# Altium schematic sheet 01-CM4_Conn.SchDoc(01-CM4_Conn)
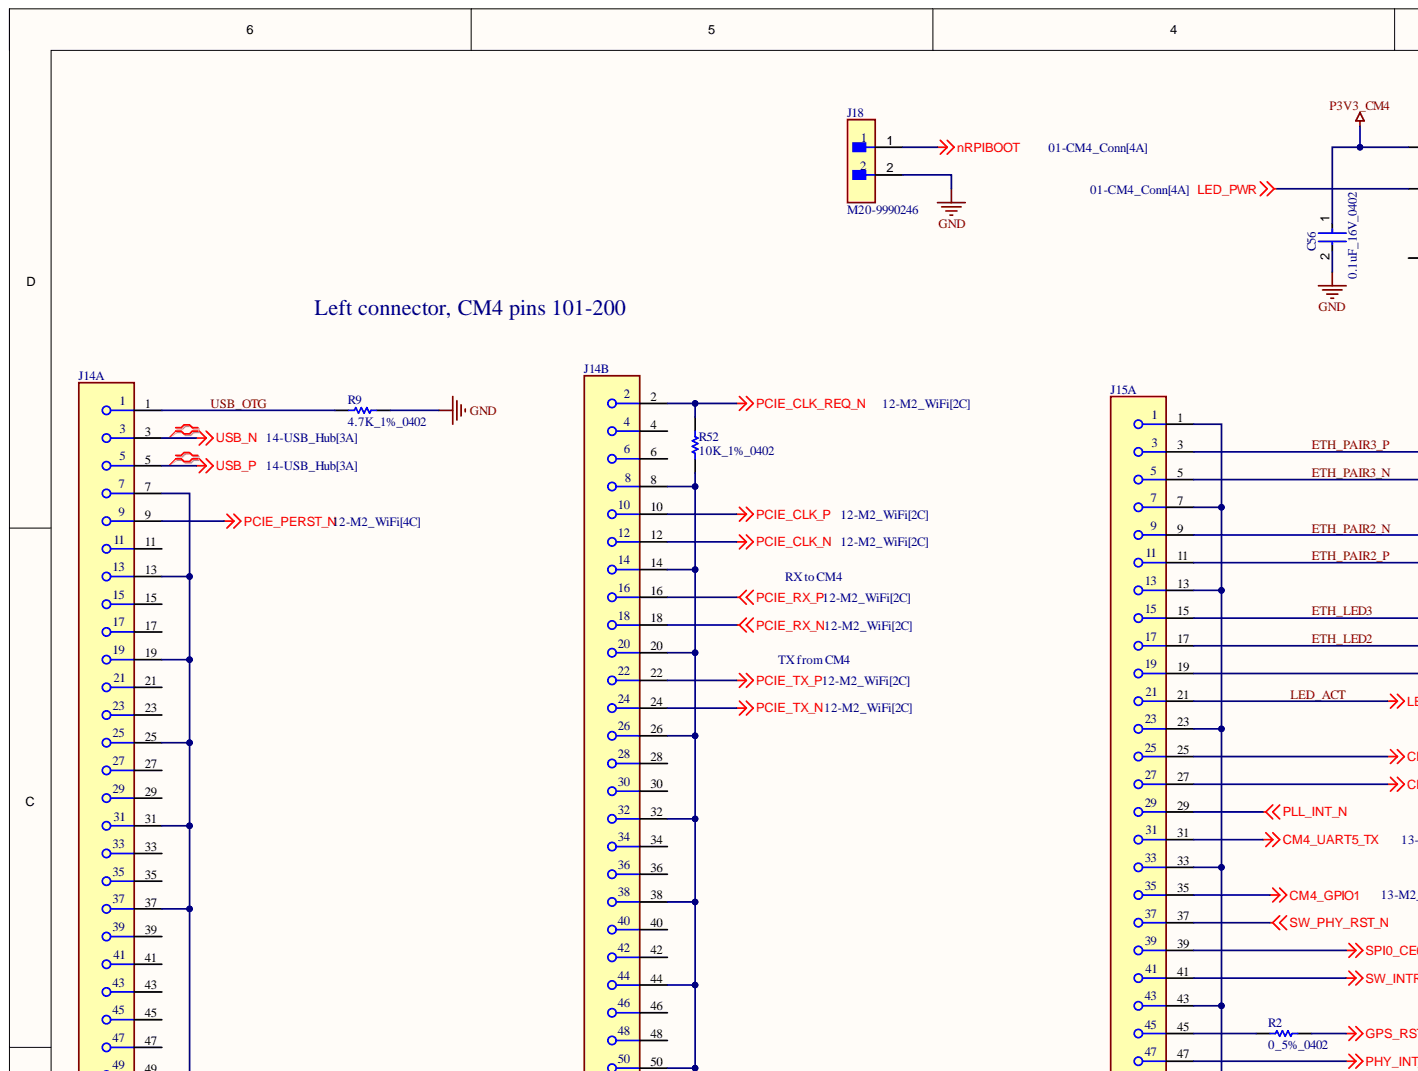
[diagram p0: 01-CM4_Conn.SchDoc, top left]
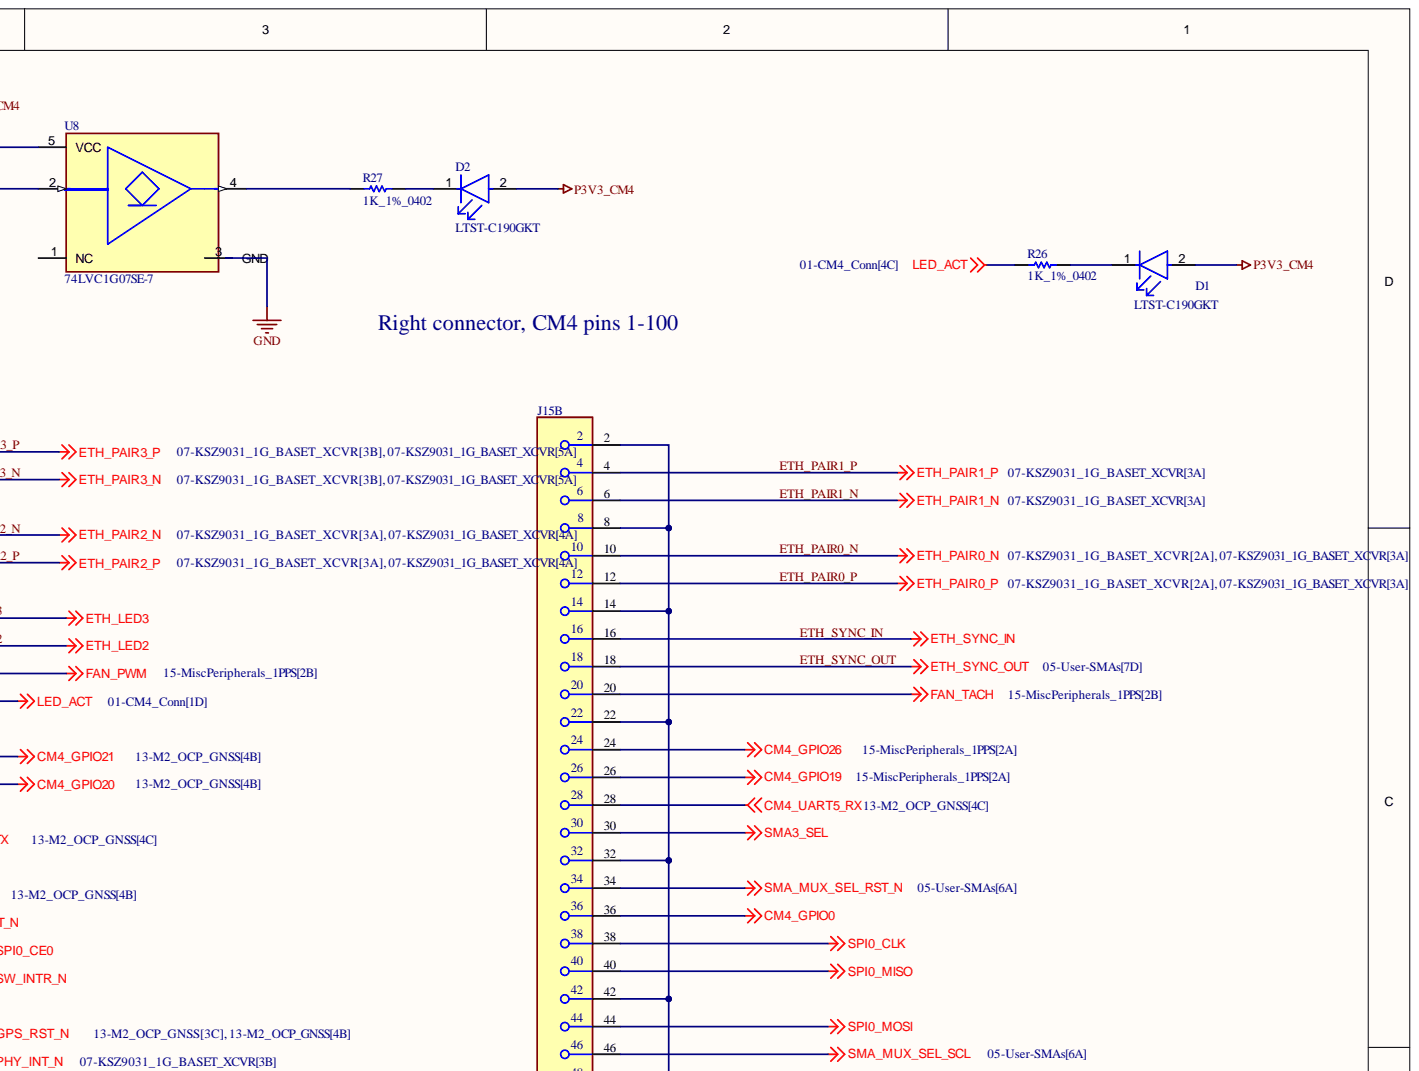
[diagram p1: 01-CM4_Conn.SchDoc, top right]
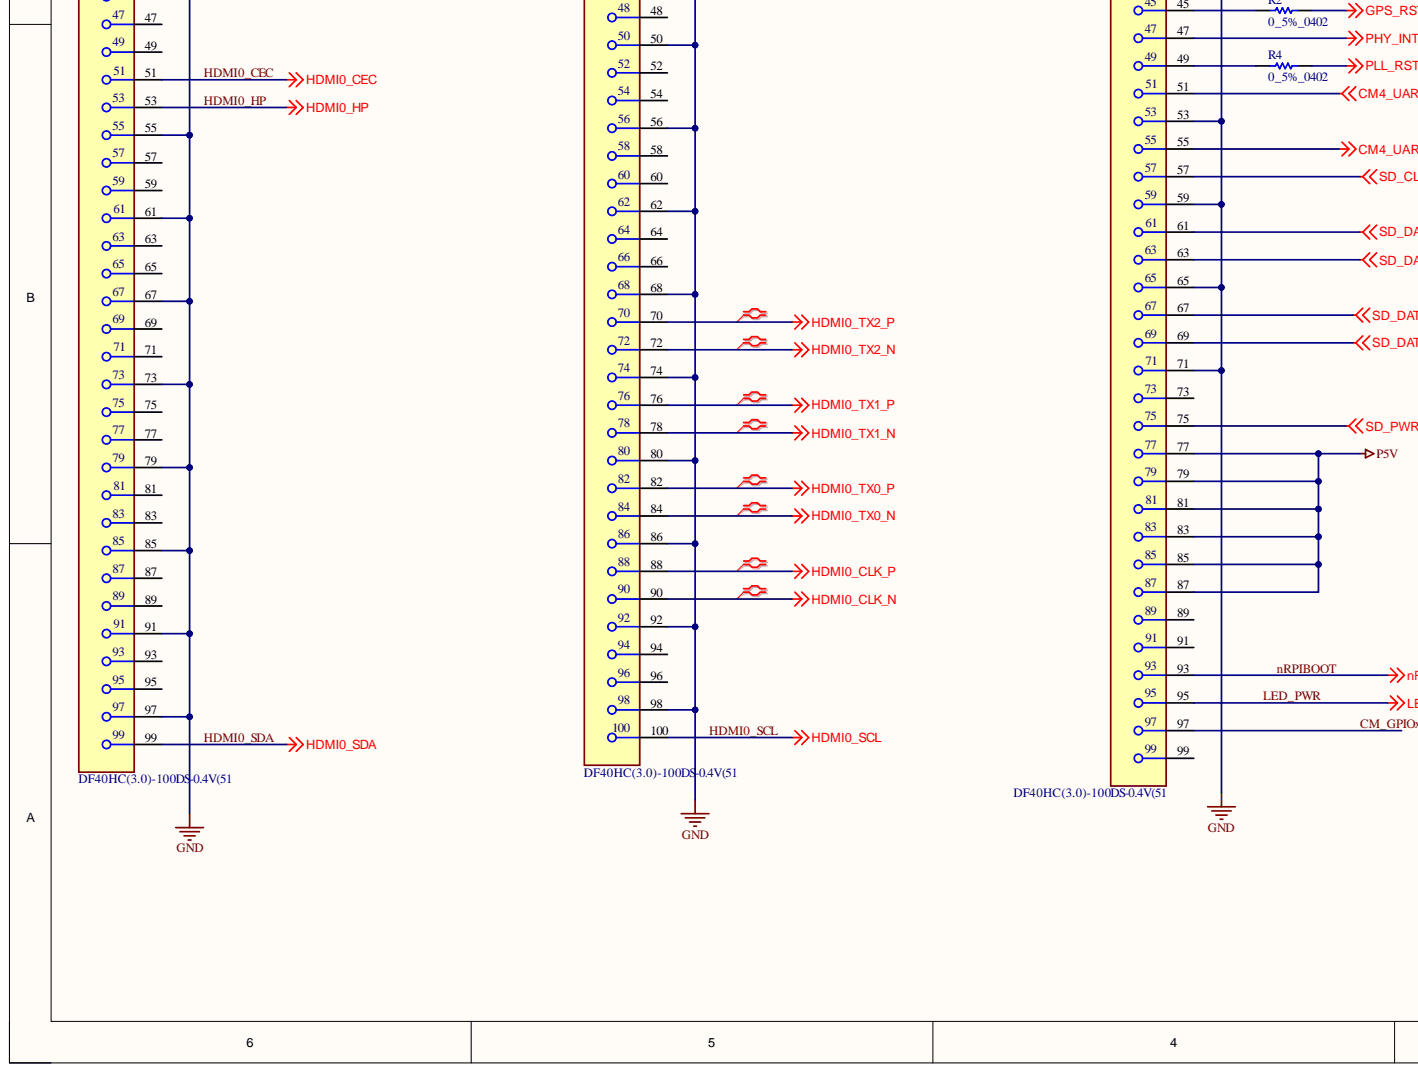
[diagram p2: 01-CM4_Conn.SchDoc, bottom left]
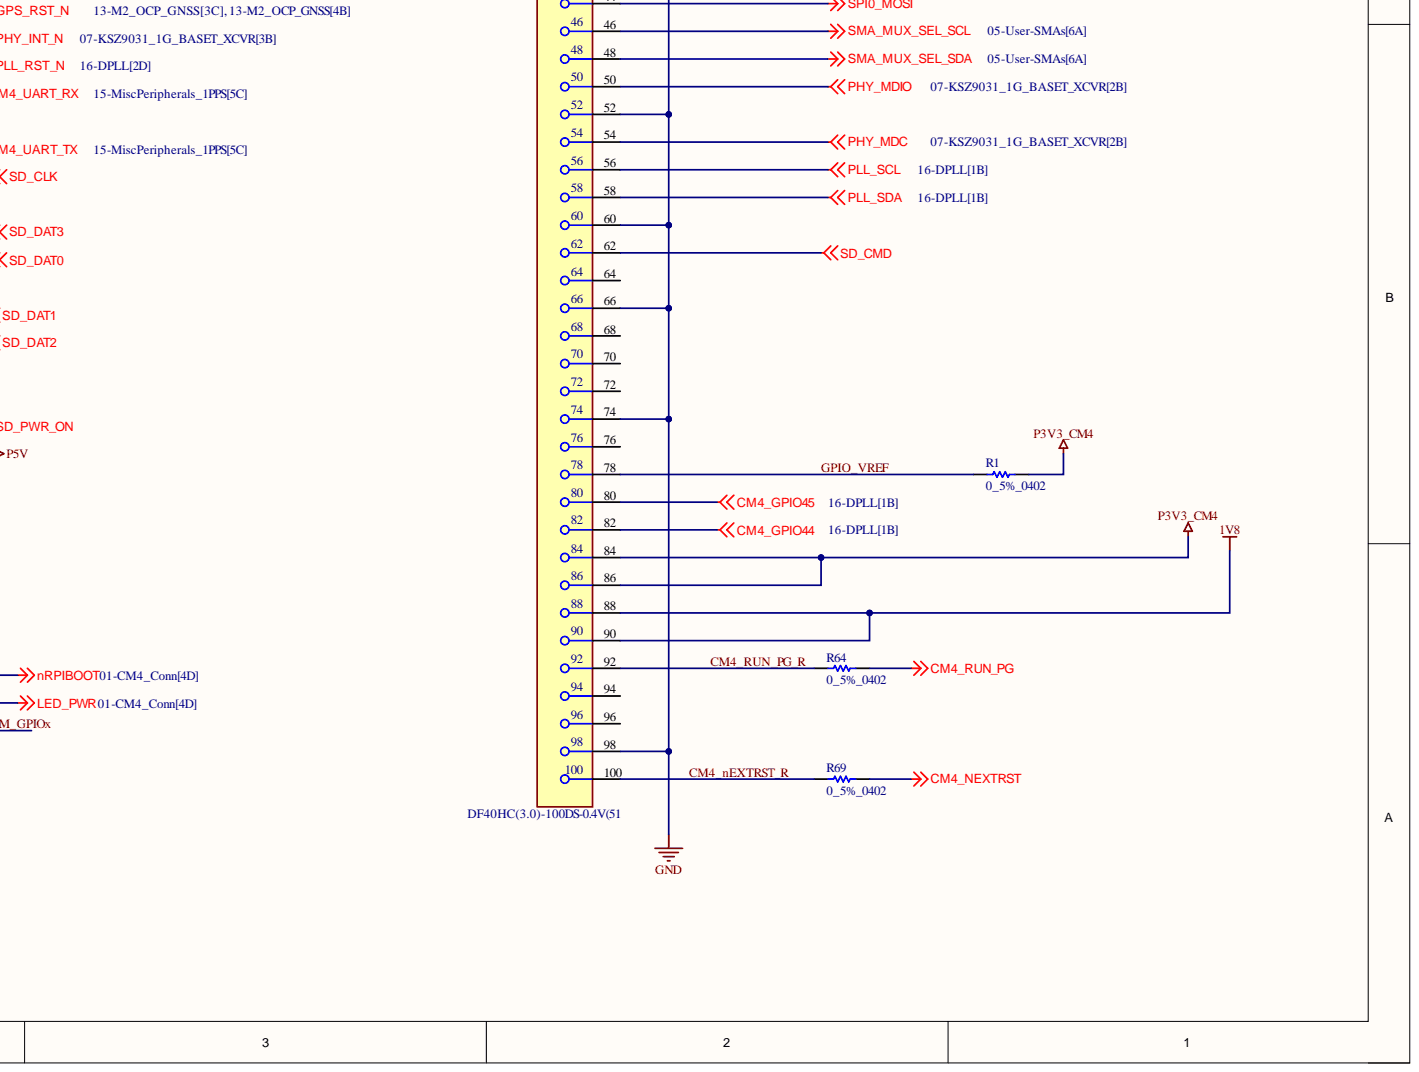
[diagram p3: 01-CM4_Conn.SchDoc, bottom right]

## Parts
C56  0.1uF_16V_0402  [FP-C0402-BB-MFG]
D1  LTST-C190GKT  [FP-LTST-C190GKT-MFG]
D2  LTST-C190GKT  [FP-LTST-C190GKT-MFG]
J14  DF40HC(3.0)-100DS-0.4V(51  [FP-DF40HC_3_0_-100DS-0_4V-MFG]  (parts A,B)
J15  DF40HC(3.0)-100DS-0.4V(51  [FP-DF40HC_3_0_-100DS-0_4V-MFG]  (parts A,B)
J18  M20-9990246  [FP-M20-9990246-MFG]
R1  0_5%_0402  [RESC1005X40X25NL05T10]
R2  0_5%_0402  [RESC1005X40X25NL05T10]
R4  0_5%_0402  [RESC1005X40X25NL05T10]
R9  4.7K_1%_0402  [RESC1005X40X25NL10T10]
R26  1K_1%_0402  [RESC1005X40X25ML05T10]
R27  1K_1%_0402  [RESC1005X40X25ML05T10]
R52  10K_1%_0402  [RESC1005X40X25NL10T10]
R64  0_5%_0402  [RESC1005X40X25NL05T10]
R69  0_5%_0402  [RESC1005X40X25NL05T10]
U8  74LVC1G07SE-7  [FP-SOT353-MFG]

## Nets
1V8: J15.88 J15.90
CM4_GPIO0: J15.36
CM4_GPIO1: J15.35
CM4_GPIO19: J15.26
CM4_GPIO20: J15.27
CM4_GPIO21: J15.25
CM4_GPIO26: J15.24
CM4_GPIO44: J15.82
CM4_GPIO45: J15.80
CM4_NEXTRST: R69.1
CM4_RUN_PG: R64.1
CM4_UART5_RX: J15.28
CM4_UART5_TX: J15.31
CM4_UART_RX: J15.51
CM4_UART_TX: J15.55
FAN_PWM: J15.19
FAN_TACH: J15.20
GND: C56.2 J14.7 J14.8 J14.13 J14.14 J14.19 J14.20 J14.25 J14.26 J14.31 J14.32 J14.37 J14.38 J14.44 J14.50 J14.55 J14.56 J14.61 J14.62 J14.67 J14.68 J14.73 J14.74 J14.79 J14.80 J14.85 J14.86 J14.91 J14.92 J14.97 J14.98 J15.1 J15.2 J15.7 J15.8 J15.13 J15.14 J15.22 J15.23 J15.32 J15.33 J15.42 J15.43 J15.52 J15.53 J15.59 J15.60 J15.65 J15.66 J15.71 J15.74 J15.98 J18.2 R9.1 R52.2
GPS_RST_N: R2.1
HDMI0_CLK_N: J14.90
HDMI0_CLK_P: J14.88
HDMI0_TX0_N: J14.84
HDMI0_TX0_P: J14.82
HDMI0_TX1_N: J14.78
HDMI0_TX1_P: J14.76
HDMI0_TX2_N: J14.72
HDMI0_TX2_P: J14.70
P3V3_CM4: C56.1 D1.2 D2.2 J15.84 J15.86 R1.1 U8.5(VCC)
P5V: J15.77 J15.79 J15.81 J15.83 J15.85 J15.87
PCIE_CLK_N: J14.12
PCIE_CLK_P: J14.10
PCIE_CLK_REQ_N: J14.2 R52.1
PCIE_PERST_N: J14.9
PCIE_RX_N: J14.18
PCIE_RX_P: J14.16
PCIE_TX_N: J14.24
PCIE_TX_P: J14.22
PHY_INT_N: J15.47
PHY_MDC: J15.54
PHY_MDIO: J15.50
PLL_INT_N: J15.29
PLL_RST_N: R4.1
PLL_SCL: J15.56
PLL_SDA: J15.58
SD_CLK: J15.57
SD_CMD: J15.62
SD_DAT0: J15.63
SD_DAT1: J15.67
SD_DAT2: J15.69
SD_DAT3: J15.61
SD_PWR_ON: J15.75
SMA3_SEL: J15.30
SMA_MUX_SEL_RST_N: J15.34
SMA_MUX_SEL_SCL: J15.46
SMA_MUX_SEL_SDA: J15.48
SPI0_CE0: J15.39
SPI0_CLK: J15.38
SPI0_MISO: J15.40
SPI0_MOSI: J15.44
SW_INTR_N: J15.41
SW_PHY_RST_N: J15.37
USB_N: J14.3
USB_P: J14.5
CM4_nEXTRST_R: J15.100 R69.2
CM4_RUN_PG_R: J15.92 R64.2
CM_GPIOx: J15.97
ETH_LED2: J15.17
ETH_LED3: J15.15
ETH_PAIR0_N: J15.10
ETH_PAIR0_P: J15.12
ETH_PAIR1_N: J15.6
ETH_PAIR1_P: J15.4
ETH_PAIR2_N: J15.9
ETH_PAIR2_P: J15.11
ETH_PAIR3_N: J15.5
ETH_PAIR3_P: J15.3
ETH_SYNC_IN: J15.16
ETH_SYNC_OUT: J15.18
GPIO_VREF: J15.78 R1.2
HDMI0_CEC: J14.51
HDMI0_HP: J14.53
HDMI0_SCL: J14.100
HDMI0_SDA: J14.99
LED_ACT: J15.21 R26.2
LED_PWR: J15.95 U8.2
nRPIBOOT: J15.93 J18.1
USB_OTG: J14.1 R9.2
NetD1_1: D1.1 R26.1
NetD2_1: D2.1 R27.1
NetJ15_45: J15.45 R2.2
NetJ15_49: J15.49 R4.2
NetR27_2: R27.2 U8.4

Not connected: J14 4 6 11 15 17 21 23 27 28 29 30 33 34 35 36 39 40 41 42 43 45 46 47 48 49 52 54 57 58 59 60 63 64 65 66 69 71 75 77 81 83 87 89 93 94 95 96; J15 64 68 70 72 73 76 89 91 94 96 99; U8 1(NC) 3

## Library data of the parts
C56 = CMP-1034-02068-3: CAP CER 0.1UF 16V 5% X7R 0402; Manufacturer: Kemet; Manufacturer Part Number: C0402C104J4RACTU; Capacitance: 0.10 μF; Material: Ceramic; Temperature Coefficient: 15%; Tolerance: 0.05; Value: 0.10 μF; Automotive: No; Category: Cap; Component Kind: Standard; ComponentLink2Description: Datasheet; ComponentLink2URL: https://content.kemet.com/datasheets/KEM_C1002_X7R_SMD.pdf; Device Class L1: Passive Components; Device Class L2: Capacitors; Device Class L3: Ceramic Capacitors; Digikey Description: CAP CER 0.1UF 16V 5% X7R 0402; Height: 0.55mm; Ipc Land Pattern Name: CAPC10050X50; Lead Free: Yes; Library Name: Altium Content Vault; Mouser Description: CAP CER 0.1UF 16V X7R 0402; Octopart: https://octopart.com/c0402c104j4ractu-kemet-12573893; Package: C0402-BB; RoHS: TRUE; Temperature: -55°C to +125°C; Temperature Characteristic: X7R; Temperature Range High: +125°C; Temperature Range Low: -55°C; Voltage Rating: 16 V
D1 D2 = CMP-22017-000005-2: LED GREEN CLEAR CHIP SMD; Dominant Wavelength: 569nm; Forward Current: 30mA; Forward Voltage: 2.1V; Lens Color: Colorless; Luminous Intensity: 6mcd; Number of Elements: 1; Power Dissipation: 100mW; Reverse Voltage: 5V; Test Current: 10mA; Viewing Angle: 130°; Voltage: 2V; Wavelength: 565nm; Case Code (Imperial): 0603; Case Code (Metric): 1608; Case/Package: 0603; Color: Green; Component Kind: Standard; Depth: 0.8mm; Height: 0.8mm; Illumination Color: Green; Lead Free: Lead Free; Length: 1.6mm; Lens Style: Clear; Lens Transparency: Clear; Library Name: Altium Content Vault; Max Operating Temperature: 85°C; Min Operating Temperature: -55°C; Mount: Surface Mount; Number of LEDs: 1; Number of Pins: 2; Package Quantity: 1; Packaging: Tape and Reel; Power Rating: 100mW; Radiation Hardening: No; Width: 0.8mm
J14 J15 = CMP-11605-000071-1: CONN RCPT 100POS SMD GOLD; Connector Type: Receptacle; Number of Positions: 100; Pitch: 0.016inch; Component Kind: Standard; Contact Plating: Gold; Library Name: Altium Content Vault; Mount: Surface Mount; Number of Rows: 2; Package Quantity: 3000; Packaging: Tape and Reel; Series: DF40
J18 = CMP-15831-000009-1: CONN HEADER VERT 2POS 2.54MM; Manufacturer: Harwin; Conn Gender: Male; Conn Orientation: Vertical; Current Rating: 3A; Number Of Contacts: 2; Automotive: No; Category: Conn; Component Kind: Standard; ComponentLink2Description: Datasheet; ComponentLink2URL: https://cdn.harwin.com/pdfs/M20-999.pdf; Contact Material: Tin,Nickel; Device Class L1: Connectors; Device Class L2: Headers and Wire Housings; Device Class L3: unset; Digikey Description: CONN HEADER VERT 2POS 2.54MM; Height: 8.99mm; Lead Free: Yes; Library Name: Altium Content Vault; Manufacturer Part Number: M20-9990246; Mouser Description: 2.54mm (0.1") Pitch SIL Vertical PC Tail Pin Header, 6.1mm mating pin height, tin, 2 contacts; Number Of Rows: 1; Octopart: https://octopart.com/m20-9990246-harwin-2590635; Package: M20-9990246; Pitch: 2.54mm; RoHS: TRUE; Temperature: -40°C to +105°C; Temperature Range High: +105°C; Temperature Range Low: -40°C
R1 R2 R4 R64 R69 = CMP-2002-06457-1: Manufacturer: Panasonic; Part Number: ERJ-U020R00X; Component Kind: Standard; Library Name: Altium Content Vault
R9 = CMP-2002-05217-1: Manufacturer: Bourns; Part Number: CR0402-FX-4701GLF; Component Kind: Standard; Library Name: Altium Content Vault
R26 R27 = CMP-2002-06478-1: Manufacturer: Multicomp; Part Number: MCMR04X1001FTL; Component Kind: Standard; Library Name: Altium Content Vault
R52 = CMP-2002-04694-1: Manufacturer: Bourns; Part Number: CR0402-FX-1002GLF; Component Kind: Standard; Library Name: Altium Content Vault
U8 = CMP-12739-000001-1: IC BUF NON-INVERT 5.5V SOT353; Manufacturer: Diodes Inc.; Logic Function Desc: Non-Inverting; Max Junction Temp: +150°C; Min Supply Voltage: 1.65V; Nominal Supply Current: 0.1uA; Number Of Circuits: 1; Propagation Delay: 1.7ns; Standoff Height: 0mm; Ambient Temperature Range High: +125°C; Ambient Temperature Range Low: -40°C; Automotive: No; Category: IC; Component Kind: Standard; ComponentLink2Description: Datasheet; ComponentLink2URL: https://www.diodes.com/assets/Datasheets/74LVC1G07.pdf; Device Class L1: Integrated Circuits (ICs); Device Class L2: Logic ICs; Device Class L3: Buffers; Digikey Description: IC BUF NON-INVERT 5.5V SOT353; Footprint Url: https://www.diodes.com/assets/Package-Files/SOT353.pdf; Height: 1.1mm; Ipc Land Pattern Name: SOT65P210X100-5; Lead Free: Yes; Library Name: Altium Content Vault; Manufacturer Part Number: 74LVC1G07SE-7; Max Supply Voltage: 5.5V; Mouser Description: 74LVC Series 5.5 V Surface Mount Single Buffer with Open Drain Output - SOT-353; Number Of Inputs: 1; Number Of Outputs: 1; Octopart: https://octopart.com/74lvc1g07se-7-diodes+inc.-18882698; Package: SOT353; RoHS: TRUE; Temperature: -40°C to +125°C; Temperature Range High: +125°C; Temperature Range Low: -40°C
